# Altium schematic sheet Sway Control Rev C - Sheet 1.SchDoc
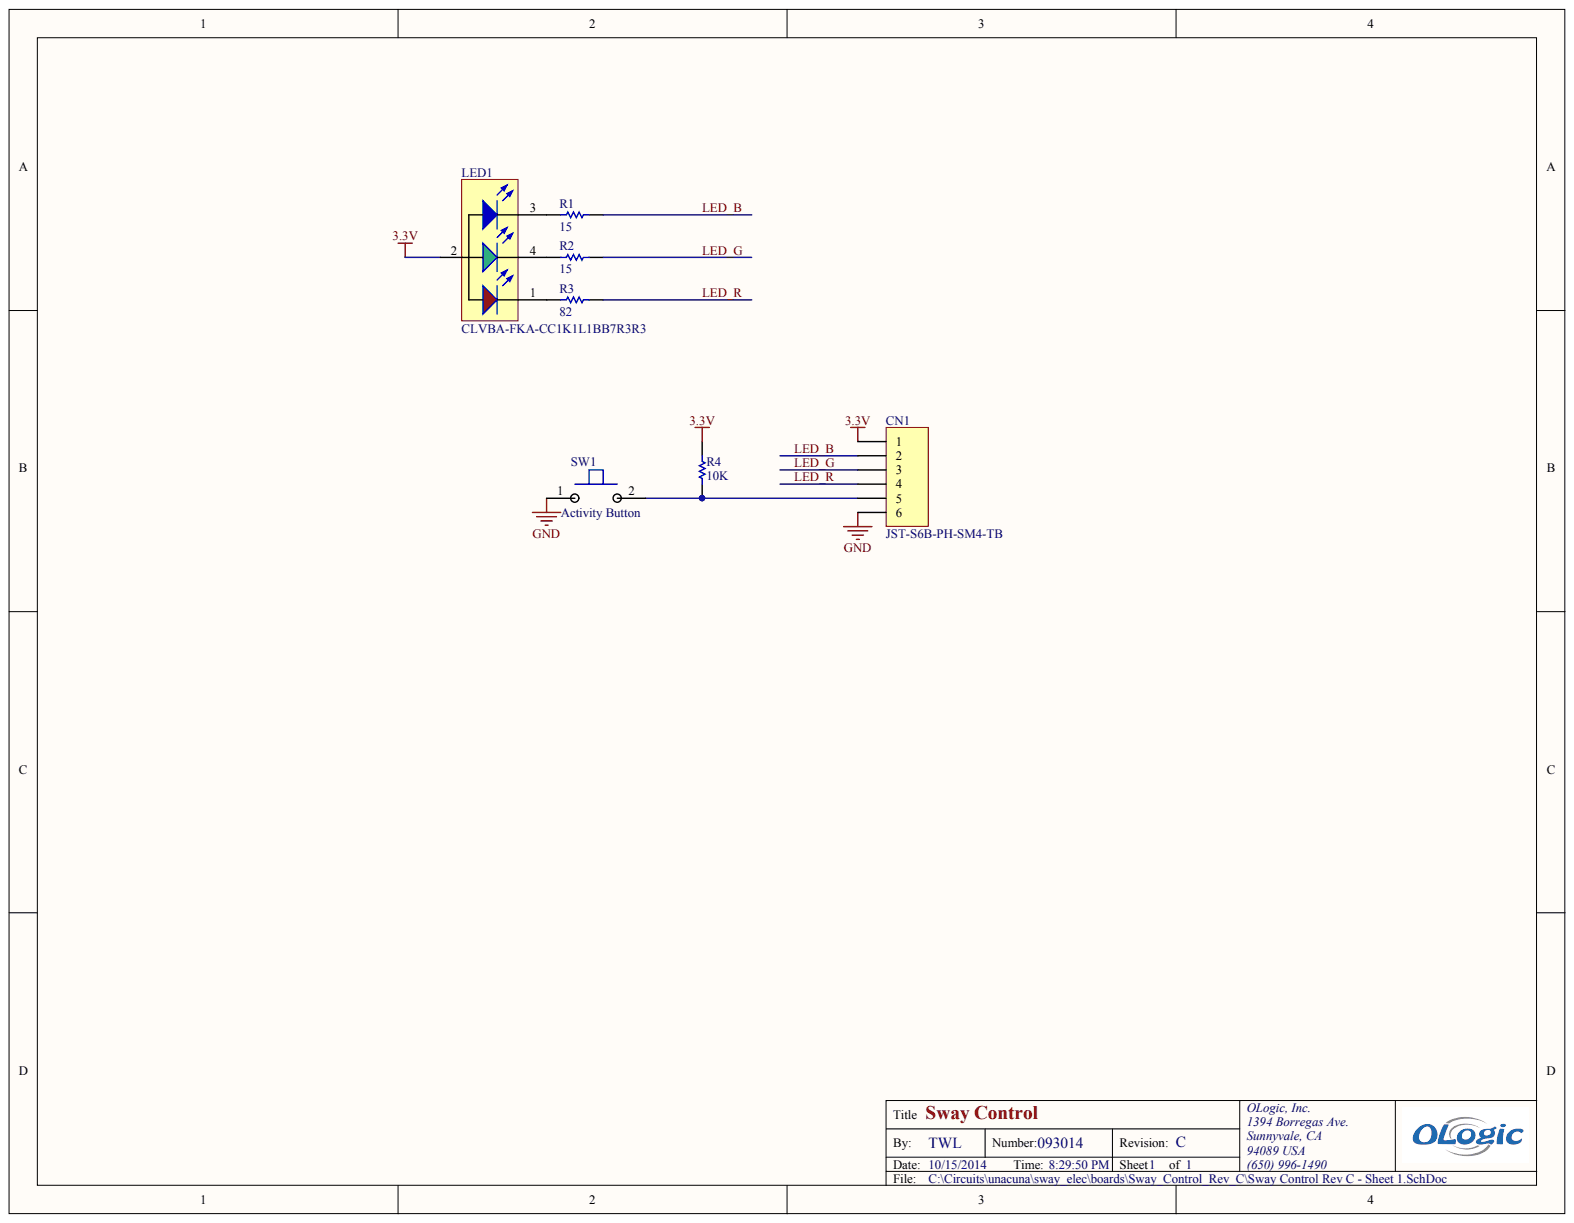
[diagram p0: Sway Control Rev C - Sheet 1.SchDoc]

## Parts
CN1  JST-S6B-PH-SM4-TB
LED1  CLVBA-FKA-CC1K1L1BB7R3R3
R1  15
R2  15
R3  82
R4  10K
SW1  Activity Button

## Nets
3.3V: CN1.1 LED1.2 R4.2
GND: CN1.6 SW1.1
LED_B: CN1.2 R1.1
LED_G: CN1.3 R2.1
LED_R: CN1.4 R3.1
NetCN1_5: CN1.5 R4.1 SW1.2
NetLED1_1: LED1.1 R3.2
NetLED1_3: LED1.3 R1.2
NetLED1_4: LED1.4 R2.2

## Library data of the parts
CN1 = (no symbol name): Manufacturer: JST Sales America Inc; Manufacturer Part Number: S6B-PH-SM4-TB(LF)(SN); Connector Type: Header, Shrouded; Number of Positions: 6; Number of Positions Loaded: All; Pitch: 0.079" (2.00mm); Supplier 1: Digi-Key; RoHS: RoHS Compliant; Category: Connectors, Interconnects; Stock 1: 9674; Pricing 1: 1=0.85, 10=0.751, 25=0.7064, 50=0.677, 100=0.6476, 250=0.58868, 500=0.52982 (USD); Packaging: Cut Tape (CT); Contact Type: Male Pin; Number of Rows: 1; Row Spacing: -; Contact Mating Length: 0.130" (3.30mm); Mounting Type: Surface Mount, Right Angle; Termination: Solder; Fastening Type: Detent Lock; Features: Solder Retention; Contact Finish: Tin; Contact Finish Thickness: -; Color: Natural; ComponentLink1URL: http://www.jst-mfg.com/product/pdf/eng/ePH.pdf; ComponentLink1Description: http://www.jst-mfg.com/product/pdf/eng/ePH.pdf; Supplier Part Number 1: 455-1753-1-ND
LED1 = (no symbol name): Manufacturer: Cree, Inc.; MPN: CLVBA-FKA-CC1F1L1BB7R3R3; PN: CLVBA-FKA-CC1F1L1BB7R3R3CT-ND; Vendor: Digikey; Color: Red, Green, Blue (RGB); Wavelength - Dominant: 622nm, 530nm, 470nm; Millicandela Rating: 168mcd Red, 337mcd Green, 84mcd Blue; Voltage - Forward (Vf) (Typ): 1.9V Red, 3V Green, 3V Blue; Current - Test: 20mA; Viewing Angle: 110°; Lens Type: Diffused, White; Lens Style/Size: Round with Flat Top, 2.2mm; Supplier 1: Digi-Key; Manufacturer Part Number: CLVBA-FKA-CC1F1L1BB7R3R3; RoHS: RoHS Compliant; Category: Optoelectronics; Stock 1: 6783; Pricing 1: 1=0.65, 50=0.6, 100=0.575, 250=0.525, 500=0.475, 1000=0.425 (USD); Packaging: Cut Tape (CT); Wavelength - Peak: -; Package / Case: 4-PLCC; Size / Dimension: 3.20mm L x 2.80mm W; Height: 2.10mm; Mounting Type: Surface Mount; Luminous Flux @ Current - Test: -; ComponentLink1URL: http://www.cree.com/~/media/Files/Cree/LED%20Components%20and%20Modules/HB/Data%20Sheets/CLVBAFKA.pdf; ComponentLink1Description: http://www.cree.com/~/media/Files/Cree/LED%20Components%20and%20Modules/HB/Data%20Sheets/CLVBAFKA.pdf; Supplier Part Number 1: CLVBA-FKA-CC1F1L1BB7R3R3CT-ND
R1 R2 = (no symbol name): Manufacturer: Panasonic Electronic Components; Manufacturer Part Number: ERJ-6GEYJ150V; Tolerance: ±5%; Power (Watts): 0.125W, 1/8W; Temperature Coefficient: ±200ppm/°C; Supplier 1: Digi-Key; RoHS: RoHS Compliant; Category: Resistors; Stock 1: 89882; Pricing 1: 1=0.1, 50=0.0196, 100=0.0149, 250=0.01104, 500=0.00816, 1000=0.00544, 2500=0.00459 (USD); Packaging: Cut Tape (CT); Resistance (Ohms): 15; Composition: Thick Film; Features: -; Package / Case: 0805 (2012 Metric); Supplier Device Package: 0805; Size / Dimension: 0.079" L x 0.049" W (2.00mm x 1.25mm); Height: 0.028" (0.70mm); Number of Terminations: 2; ComponentLink1URL: http://industrial.panasonic.com/www-cgi/jvcr13pz.cgi?E+PZ+3+AOA0001+ERJ6GEYJ150V+7+WW; ComponentLink1Description: http://industrial.panasonic.com/www-cgi/jvcr13pz.cgi?E+PZ+3+AOA0001+ERJ6GEYJ150V+7+WW; Supplier Part Number 1: P15ACT-ND
R3 = (no symbol name): Manufacturer: Panasonic Electronic Components; Manufacturer Part Number: ERJ-6GEYJ820V; Tolerance: ±5%; Power (Watts): 0.125W, 1/8W; Temperature Coefficient: ±200ppm/°C; Supplier 1: Digi-Key; RoHS: RoHS Compliant; Category: Resistors; Stock 1: 32275; Pricing 1: 1=0.1, 50=0.0196, 100=0.0149, 250=0.01104, 500=0.00816, 1000=0.00544, 2500=0.00459 (USD); Packaging: Cut Tape (CT); Resistance (Ohms): 82; Composition: Thick Film; Features: -; Package / Case: 0805 (2012 Metric); Supplier Device Package: 0805; Size / Dimension: 0.079" L x 0.049" W (2.00mm x 1.25mm); Height: 0.028" (0.70mm); Number of Terminations: 2; ComponentLink1URL: http://industrial.panasonic.com/www-cgi/jvcr13pz.cgi?E+PZ+3+AOA0001+ERJ6GEYJ820V+7+WW; ComponentLink1Description: http://industrial.panasonic.com/www-cgi/jvcr13pz.cgi?E+PZ+3+AOA0001+ERJ6GEYJ820V+7+WW; Supplier Part Number 1: P82ACT-ND
R4 = (no symbol name): Manufacturer: Panasonic Electronic Components; Manufacturer Part Number: ERJ-6GEYJ103V; Tolerance: ±5%; Power (Watts): 0.125W, 1/8W; Temperature Coefficient: ±200ppm/°C; Supplier 1: Digi-Key; RoHS: RoHS Compliant; Category: Resistors; Stock 1: 5233211; Pricing 1: 1=0.1, 50=0.0196, 100=0.0149, 250=0.01104, 500=0.00816, 1000=0.00544, 2500=0.00459 (USD); Packaging: Cut Tape (CT); Resistance (Ohms): 10k; Composition: Thick Film; Features: -; Package / Case: 0805 (2012 Metric); Supplier Device Package: 0805; Size / Dimension: 0.079" L x 0.049" W (2.00mm x 1.25mm); Height: 0.028" (0.70mm); Number of Terminations: 2; ComponentLink1URL: http://industrial.panasonic.com/www-cgi/jvcr13pz.cgi?E+PZ+3+AOA0001+ERJ6GEYJ103V+7+WW; ComponentLink1Description: http://industrial.panasonic.com/www-cgi/jvcr13pz.cgi?E+PZ+3+AOA0001+ERJ6GEYJ103V+7+WW; Supplier Part Number 1: P10KACT-ND
SW1 = (no symbol name): Manufacturer: TE Connectivity; Manufacturer Part Number: 1-1825910-0; Vendor: Digikey; Circuit: SPST-NO; Switch Function: Off-Mom; Contact Rating @ Voltage: 0.05A @ 24VDC; Actuator Type: Standard; Actuator Height off PCB, from Pins: 7.00mm; Actuator Orientation: Top Actuated; Outline: 6.00mm x 6.00mm; Illumination: Non-Illuminated; Operating Force: 160gf; Actuator Material: Polyamide (PA66), Nylon 6/6; Switch Travel: 0.25mm; Mechanical Life: 100,000 Cycles; Supplier 1: Digi-Key; Supplier Part Number 1: 450-1652-ND; RoHS: RoHS Compliant; Category: Switches; Stock 1: 38756; Pricing 1: 1=0.12, 10=0.111, 25=0.1076, 50=0.1036, 100=0.0979, 250=0.09216, 500=0.08832, 1000=0.0672 (USD); Packaging: Bulk; Mounting Type: Through Hole; Termination Style: PC Pin; Illumination Voltage (Nominal): -; Illumination Type, Color: -; Ingress Protection: -; Features: -; Operating Temperature: -35°C ~ 85°C; ComponentLink1URL: http://www.te.com/commerce/DocumentDelivery/DDEController?Action=srchrtrv&DocNm=1825910&DocType=Customer+Drawing&DocLang=English; ComponentLink1Description: http://www.te.com/commerce/DocumentDelivery/DDEController?Action=srchrtrv&DocNm=1825910&DocType=Customer+Drawing&DocLang=English
